# Altium schematic sheet DEVICES1.SchDoc
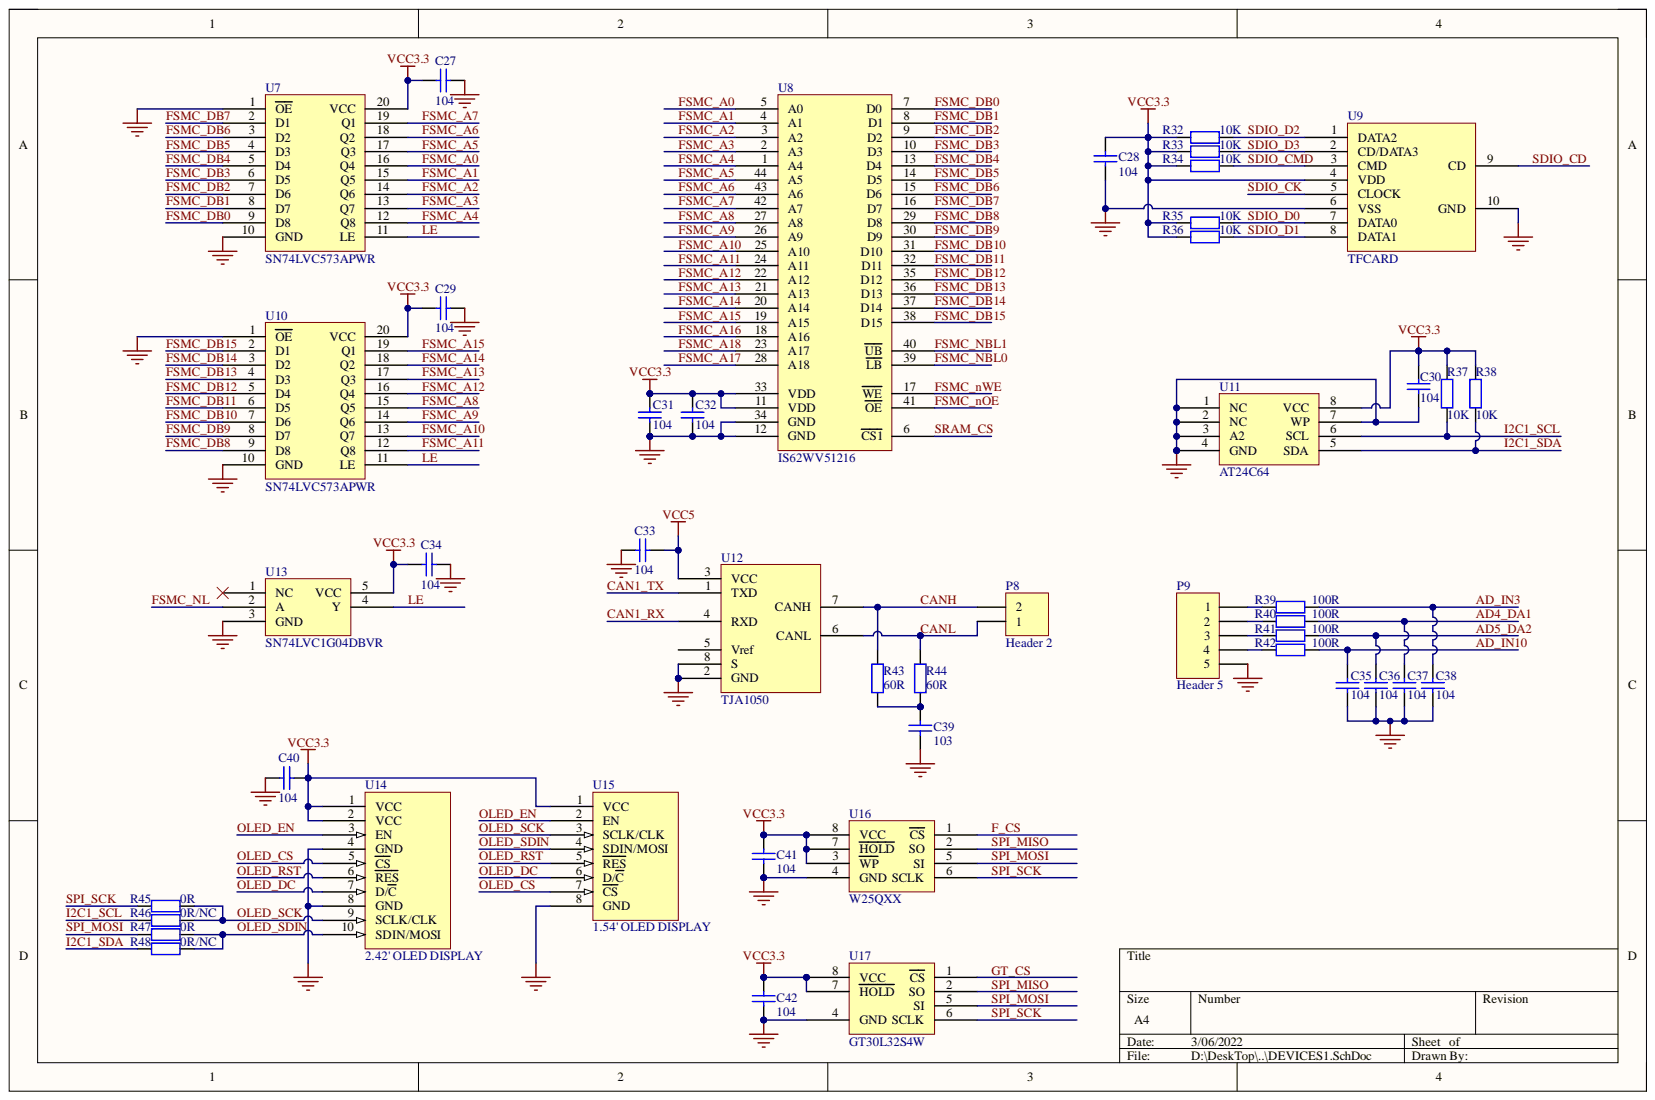
[diagram p0: DEVICES1.SchDoc]

## Parts
C27  104  [C0603]
C28  104  [C0603]
C29  104  [C0603]
C30  104  [C0603]
C31  104  [C0603]
C32  104  [C0603]
C33  104  [C0603]
C34  104  [C0603]
C35  104  [C0603]
C36  104  [C0603]
C37  104  [C0603]
C38  104  [C0603]
C39  103  [C0805]
C40  104  [C0603]
C41  104  [C0603]
C42  104  [C0603]
P8  Header 2  [XH2P54P2]
P9  Header 5  [HDR-TH_5P-P2.54-V-M]
R32  10K  [R0603]
R33  10K  [R0603]
R34  10K  [R0603]
R35  10K  [R0603]
R36  10K  [R0603]
R37  10K  [R0603]
R38  10K  [R0603]
R39  100R  [R0805]
R40  100R  [R0805]
R41  100R  [R0805]
R42  100R  [R0805]
R43  60R  [R0805]
R44  60R  [R0805]
R45  0R  [R0805]
R46  0R/NC  [R0805]
R47  0R  [R0805]
R48  0R/NC  [R0805]
U7  SN74LVC573APWR  [TSSOP20]
U8  IS62WV51216  [TSOP-44N]
U9  TFCARD  [TF-CARD]
U10  SN74LVC573APWR  [TSSOP20]
U11  AT24C64  [SOP-8]
U12  TJA1050  [SOP-8]
U13  SN74LVC1G04DBVR  [SOT23-5]
U14  2.42' OLED DISPLAY  [XH2P54P10]
U15  1.54' OLED DISPLAY  [XH2P54P8]
U16  W25QXX  [WSOP8]
U17  GT30L32S4W  [WSOP8]

## Nets
GND: C27.1 C28.1 C29.1 C30.1 C31.1 C32.1 C33.2 C34.1 C35.1 C36.1 C37.1 C38.1 C39.2 C40.2 C41.1 C42.1 P9.5 U7.1(OE) U7.10(GND) U8.12(GND) U8.34(GND) U9.6(VSS) U9.10(GND) U10.1(OE) U10.10(GND) U11.1(NC) U11.2(NC) U11.3(A2) U11.4(GND) U11.7(WP) U12.2(GND) U12.8(S) U13.3(GND) U14.4(GND) U14.8(GND) U15.8(GND) U16.4(GND) U17.4(GND)
VCC3.3: C27.2 C28.2 C29.2 C30.2 C31.2 C32.2 C34.2 C40.1 C41.2 C42.2 R32.2 R33.2 R34.2 R35.2 R36.2 R37.2 R38.2 U7.20(VCC) U8.11(VDD) U8.33(VDD) U9.4(VDD) U10.20(VCC) U11.8(VCC) U13.5(VCC) U14.1(VCC) U14.2(VCC) U15.1(VCC) U16.3(WP) U16.7(HOLD) U16.8(VCC) U17.7(HOLD) U17.8(VCC)
VCC5: C33.1 U12.3(VCC)
AD4_DA1: C37.2 R40.1
AD5_DA2: C36.2 R41.1
AD_IN3: C38.2 R39.1
AD_IN10: C35.2 R42.1
CAN1_RX: U12.4(RXD)
CAN1_TX: U12.1(TXD)
CANH: P8.2 R43.1 U12.7(CANH)
CANL: P8.1 R44.2 U12.6(CANL)
F_CS: U16.1(CS)
FSMC_A0: U7.16(Q4) U8.5(A0)
FSMC_A1: U7.15(Q5) U8.4(A1)
FSMC_A2: U7.14(Q6) U8.3(A2)
FSMC_A3: U7.13(Q7) U8.2(A3)
FSMC_A4: U7.12(Q8) U8.1(A4)
FSMC_A5: U7.17(Q3) U8.44(A5)
FSMC_A6: U7.18(Q2) U8.43(A6)
FSMC_A7: U7.19(Q1) U8.42(A7)
FSMC_A8: U8.27(A8) U10.15(Q5)
FSMC_A9: U8.26(A9) U10.14(Q6)
FSMC_A10: U8.25(A10) U10.13(Q7)
FSMC_A11: U8.24(A11) U10.12(Q8)
FSMC_A12: U8.22(A12) U10.16(Q4)
FSMC_A13: U8.21(A13) U10.17(Q3)
FSMC_A14: U8.20(A14) U10.18(Q2)
FSMC_A15: U8.19(A15) U10.19(Q1)
FSMC_A16: U8.18(A16)
FSMC_A17: U8.28(A18)
FSMC_A18: U8.23(A17)
FSMC_DB0: U7.9(D8) U8.7(D0)
FSMC_DB1: U7.8(D7) U8.8(D1)
FSMC_DB2: U7.7(D6) U8.9(D2)
FSMC_DB3: U7.6(D5) U8.10(D3)
FSMC_DB4: U7.5(D4) U8.13(D4)
FSMC_DB5: U7.4(D3) U8.14(D5)
FSMC_DB6: U7.3(D2) U8.15(D6)
FSMC_DB7: U7.2(D1) U8.16(D7)
FSMC_DB8: U8.29(D8) U10.9(D8)
FSMC_DB9: U8.30(D9) U10.8(D7)
FSMC_DB10: U8.31(D10) U10.7(D6)
FSMC_DB11: U8.32(D11) U10.6(D5)
FSMC_DB12: U8.35(D12) U10.5(D4)
FSMC_DB13: U8.36(D13) U10.4(D3)
FSMC_DB14: U8.37(D14) U10.3(D2)
FSMC_DB15: U8.38(D15) U10.2(D1)
FSMC_NBL0: U8.39(LB)
FSMC_NBL1: U8.40(UB)
FSMC_NL: U13.2(A)
FSMC_nOE: U8.41(OE)
FSMC_nWE: U8.17(WE)
GT_CS: U17.1(CS)
I2C1_SCL: R37.1 R46.2 U11.6(SCL)
I2C1_SDA: R38.1 R48.2 U11.5(SDA)
LE: U7.11(LE) U10.11(LE) U13.4(Y)
OLED_CS: U14.5(CS) U15.7(CS)
OLED_DC: U14.7(D/C) U15.6(D/C)
OLED_EN: U14.3(EN) U15.2(EN)
OLED_RST: U14.6(RES) U15.5(RES)
OLED_SCK: R45.1 R46.1 U14.9(SCLK/CLK) U15.3(SCLK/CLK)
OLED_SDIN: R47.1 R48.1 U14.10(SDIN/MOSI) U15.4(SDIN/MOSI)
SDIO_CD: U9.9(CD)
SDIO_CK: U9.5(CLOCK)
SDIO_CMD: R34.1 U9.3(CMD)
SDIO_D0: R35.1 U9.7(DATA0)
SDIO_D1: R36.1 U9.8(DATA1)
SDIO_D2: R32.1 U9.1(DATA2)
SDIO_D3: R33.1 U9.2(CD/DATA3)
SPI_MISO: U16.2(SO) U17.2(SO)
SPI_MOSI: R47.2 U16.5(SI) U17.5(SI)
SPI_SCK: R45.2 U16.6(SCLK) U17.6(SCLK)
SRAM_CS: U8.6(CS1)
NetC39_1: C39.1 R43.2 R44.1
NetP9_1: P9.1 R39.2
NetP9_2: P9.2 R40.2
NetP9_3: P9.3 R41.2
NetP9_4: P9.4 R42.2

Not connected: U12 5(Vref); U13 1(NC)

## Library data of the parts
C27-C29 C31-C40 = Cap: Capacitor; Value: 100pF; Component Kind: Standard; LatestRevisionDate: 17-Jul-2002; LatestRevisionNote: Re-released for DXP Platform.; Library Name: MainBoard.SCHLIB; PackageDescription: Radial Cap, Thru-Hole; 2 Leads; 0.3 in Pin Spacing; PackageReference: RAD-0.3; Published: 8-Jun-2000; Publisher: Altium Limited
C30 C41 C42 = CAP: Capacitor; Value: 100pF; Component Kind: Standard; LatestRevisionDate: 17-Jul-2002; LatestRevisionNote: Re-released for DXP Platform.; Library Name: MainBoard.SCHLIB; PackageDescription: Radial Cap, Thru-Hole; 2 Leads; 0.3 in Pin Spacing; PackageReference: RAD-0.3; Published: 8-Jun-2000; Publisher: Altium Limited
P8 = Header 2: Header, 2-Pin; Component Kind: Standard; LatestRevisionDate: 17-Jul-2002; LatestRevisionNote: Re-released for DXP Platform.; Library Name: MainBoard.SCHLIB; Publisher: Altium Limited
P9 = Header 5: Header, 5-Pin; Component Kind: Standard; LatestRevisionDate: 17-Jul-2002; LatestRevisionNote: Re-released for DXP Platform.; Library Name: Miscellaneous Connectors.IntLib; Signal Integrity: Connector; Publisher: Altium Limited
R32-R48 = Res: Resistor; Component Kind: Standard; LatestRevisionDate: 17-Jul-2002; LatestRevisionNote: Re-released for DXP Platform.; PackageDescription: Axial Device, Thru-Hole; 2 Leads; 0.4 in Pin Spacing; PackageReference: AXIAL-0.4; Published: 8-Jun-2000; Publisher: Altium Limited
  R32-R36 R39-R48: Library Name: MainBoard.SCHLIB
  R37 R38: Library Name: MainBoard.SchLib
U14 = OLED: 2.42' OLED DISPLAY SCREEN; Component Kind: Standard; Library Name: UV_detector_main_board.SCHLIB
U15 = OLED 1.54’: 1.54' OLED DISPLAY SCREEN; Component Kind: Standard; Library Name: UV_detector_main_board.SCHLIB
U7 U10 = SN74LVC573APWR: Component Kind: Standard; Library Name: MainBoard.SchLib
U8 = IS62WV51216: Component Kind: Standard; Library Name: MainBoard.SchLib
U9 = TFCARD: Component Kind: Standard; Library Name: MainBoard.SCHLIB
U11 = AT24C64: Component Kind: Standard; Library Name: MainBoard.SchLib
U12 = TJA1050: Component Kind: Standard; Library Name: MainBoard.SchLib
U13 = SN74LVC1G04DBVR: Component Kind: Standard; Library Name: MainBoard.SchLib
U16 = W25QXX: Component Kind: Standard; Library Name: MainBoard.SCHLIB
U17 = GT30L32S4W: Component Kind: Standard; Library Name: MainBoard.SchLib
